# Altium schematic sheet shower.SchDoc
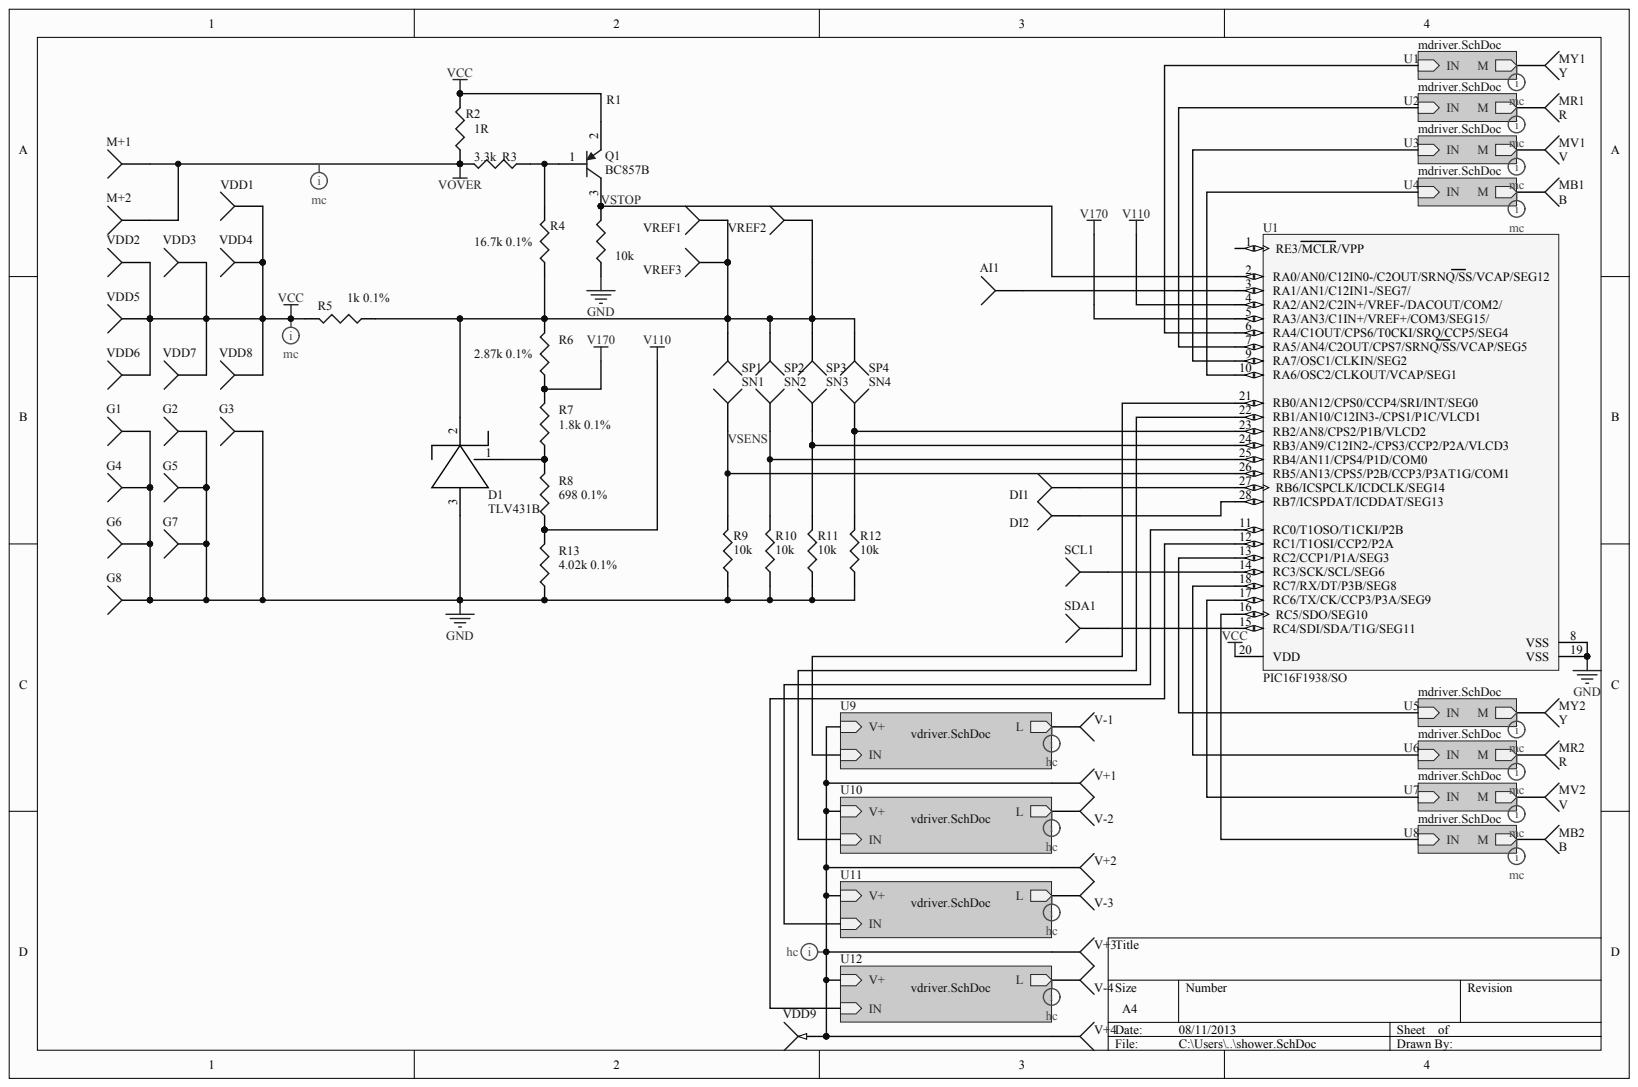
[diagram p0: shower.SchDoc]

## Parts
AI1  +5V  (value not drawn)
D1  TLV431B
DI1  +5V  (value not drawn)
DI2  +5V  (value not drawn)
G1  Socket  (value not drawn)
G2  Socket  (value not drawn)
G3  Socket  (value not drawn)
G4  Socket  (value not drawn)
G5  Socket  (value not drawn)
G6  Socket  (value not drawn)
G7  Socket  (value not drawn)
G8  Socket  (value not drawn)
M+1  +5V  (value not drawn)
M+2  +5V  (value not drawn)
MB1  B
MB2  B
MR1  R
MR2  R
MV1  V
MV2  V
MY1  Y
MY2  Y
Q1  BC857B
R1  10k
R2  1R
R3  3.3k
R4  16.7k 0.1%  value 16.7k
R5  1k 0.1%  value 1k
R6  2.87k 0.1%
R7  1.8k 0.1%  value 1.8k
R8  698 0.1%  value 698
R9  10k
R10  10k
R11  10k
R12  10k
R13  4.02k 0.1%
SCL1  +5V  (value not drawn)
SDA1  +5V  (value not drawn)
SN1  +5V  (value not drawn)
SN2  +5V  (value not drawn)
SN3  +5V  (value not drawn)
SN4  +5V  (value not drawn)
SP1  +5V  (value not drawn)
SP2  +5V  (value not drawn)
SP3  +5V  (value not drawn)
SP4  +5V  (value not drawn)
U1  PIC16F1938/SO
V+1  +5V  (value not drawn)
V+2  +5V  (value not drawn)
V+3  +5V  (value not drawn)
V+4  +5V  (value not drawn)
V-1  +5V  (value not drawn)
V-2  +5V  (value not drawn)
V-3  +5V  (value not drawn)
V-4  +5V  (value not drawn)
VDD1  +5V  (value not drawn)
VDD2  +5V  (value not drawn)
VDD3  +5V  (value not drawn)
VDD4  +5V  (value not drawn)
VDD5  +5V  (value not drawn)
VDD6  +5V  (value not drawn)
VDD7  +5V  (value not drawn)
VDD8  +5V  (value not drawn)
VDD9  +5V  (value not drawn)
VREF1  +5V  (value not drawn)
VREF2  +5V  (value not drawn)
VREF3  +5V  (value not drawn)

## Nets
GND: D1.3 G1.1 G2.1 G3.1 G4.1 G5.1 G6.1 G7.1 G8.1 R1.1 R9.1 R10.1 R11.1 R12.1 R13.1 U1.8(VSS) U1.19(VSS)
V110: R8.1 R13.2 U1.4(RA2/AN2/C2IN+/VREF-/DACOUT/COM2/)
V170: R6.1 R7.2 U1.5(RA3/AN3/C1IN+/VREF+/COM3/SEG15/)
VCC: Q1.2 R2.2 R5.2 U1.20(VDD) VDD1.1 VDD2.1 VDD3.1 VDD4.1 VDD5.1 VDD6.1 VDD7.1 VDD8.1
VOVER: M+1.1 M+2.1 R2.1 R3.2
VSENS: R9.2 SN1.1 U1.26(RB5/AN13/CPS5/P2B/CCP3/P3AT1G/COM1)
VSTOP: Q1.3 R1.2 U1.2(RA0/AN0/C12IN0-/C2OUT/SRNQ/SS/VCAP/SEG12)
NetAI1_1: AI1.1 U1.3(RA1/AN1/C12IN1-/SEG7/)
NetD1_1: D1.1 R7.1 R8.2
NetD1_2: D1.2 R4.1 R5.1 R6.2 SP1.1 SP2.1 SP3.1 SP4.1 VREF1.1 VREF2.1 VREF3.1
NetDI1_1: DI1.1 U1.27(RB6/ICSPCLK/ICDCLK/SEG14)
NetDI2_1: DI2.1 U1.28(RB7/ICSPDAT/ICDDAT/SEG13)
NetMB1_1: MB1.1
NetMB2_1: MB2.1
NetMR1_1: MR1.1
NetMR2_1: MR2.1
NetMV1_1: MV1.1
NetMV2_1: MV2.1
NetMY1_1: MY1.1
NetMY2_1: MY2.1
NetQ1_1: Q1.1 R3.1 R4.2
NetR10_2: R10.2 SN2.1 U1.25(RB4/AN11/CPS4/P1D/COM0)
NetR11_2: R11.2 SN3.1 U1.24(RB3/AN9/C12IN2-/CPS3/CCP2/P2A/VLCD3)
NetR12_2: R12.2 SN4.1 U1.23(RB2/AN8/CPS2/P1B/VLCD2)
NetSCL1_1: SCL1.1 U1.14(RC3/SCK/SCL/SEG6)
NetSDA1_1: SDA1.1 U1.15(RC4/SDI/SDA/T1G/SEG11)
NetU1_1: U1.1(RE3/MCLR/VPP)
NetU1_6: U1.6(RA4/C1OUT/CPS6/T0CKI/SRQ/CCP5/SEG4)
NetU1_7: U1.7(RA5/AN4/C2OUT/CPS7/SRNQ/SS/VCAP/SEG5)
NetU1_9: U1.9(RA7/OSC1/CLKIN/SEG2)
NetU1_10: U1.10(RA6/OSC2/CLKOUT/VCAP/SEG1)
NetU1_11: U1.11(RC0/T1OSO/T1CKI/P2B)
NetU1_12: U1.12(RC1/T1OSI/CCP2/P2A)
NetU1_13: U1.13(RC2/CCP1/P1A/SEG3)
NetU1_16: U1.16(RC5/SDO/SEG10)
NetU1_17: U1.17(RC6/TX/CK/CCP3/P3A/SEG9)
NetU1_18: U1.18(RC7/RX/DT/P3B/SEG8)
NetU1_21: U1.21(RB0/AN12/CPS0/CCP4/SRI/INT/SEG0)
NetU1_22: U1.22(RB1/AN10/C12IN3-/CPS1/P1C/VLCD1)
NetV+1_1: V+1.1 V+2.1 V+3.1 V+4.1 VDD9.1
NetV-1_1: V-1.1
NetV-2_1: V-2.1
NetV-3_1: V-3.1
NetV-4_1: V-4.1

## Library data of the parts
R2 = (no symbol name): Value: 1; Published: 8-Jun-2000; LatestRevisionDate: 29-May-2009; LatestRevisionNote: IPC-7351 Footprint Added.; PackageReference: J1-0603; PackageDescription: Chip Resistor; Publisher: Altium Limited
AI1 D1 DI1 DI2 G1-G8 M+1 M+2 MB1 MB2 MR1 MR2 MV1 MV2 MY1 MY2 Q1 R1 R3-R13 SCL1 SDA1 SN1-SN4 SP1-SP4 U1 V+1-V+4 V-1-V-4 VDD1-VDD9 VREF1-VREF3 = (no symbol name)
  AI1 DI1 DI2 G1-G8 M+1 M+2 MB1 MB2 MR1 MR2 MV1 MV2 MY1 MY2 SCL1 SDA1 SN1-SN4 SP1-SP4 V+1-V+4 V-1-V-4 VDD1-VDD9 VREF1-VREF3: LatestRevisionDate: 17-Jul-2002; LatestRevisionNote: Re-released for DXP Platform.
  Q1: Published: 8-Jun-2000; LatestRevisionDate: 29-May-2009; LatestRevisionNote: IPC-7351 Footprint Added.; PackageVersion: Aug-1999; PackageReference: SOT-23B; PackageDescription: 3-Pin SOT-23 Package 0.95 mm Pitch, 2.4 mm Lead Span
  R1 R9-R12: Published: 8-Jun-2000; LatestRevisionDate: 29-May-2009; LatestRevisionNote: IPC-7351 Footprint Added.; PackageReference: J1-0603; PackageDescription: Chip Resistor; Value: 10K
  R3: Published: 8-Jun-2000; LatestRevisionDate: 29-May-2009; LatestRevisionNote: IPC-7351 Footprint Added.; PackageReference: J1-0603; PackageDescription: Chip Resistor; Value: 3.3K
  R4 R5 R7 R8: Published: 8-Jun-2000; LatestRevisionDate: 29-May-2009; LatestRevisionNote: IPC-7351 Footprint Added.; PackageReference: J1-0603; PackageDescription: Chip Resistor
  R6: Published: 8-Jun-2000; LatestRevisionDate: 29-May-2009; LatestRevisionNote: IPC-7351 Footprint Added.; PackageReference: J1-0603; PackageDescription: Chip Resistor; Value: 2.87K
  R13: Published: 8-Jun-2000; LatestRevisionDate: 29-May-2009; LatestRevisionNote: IPC-7351 Footprint Added.; PackageReference: J1-0603; PackageDescription: Chip Resistor; Value: 4.02K
  U1: Published: 40149; LatestRevisionDate: 11-Aug-2010; LatestRevisionNote: Sch. layout revised.; DatasheetVersion: revC, Sep-2009; PackageVersion: revBB, Aug-2009; PackageReference: SOIC-SO28; PackageDescription: 28-Lead Plastic Small Outline (SO) - Wide 7.50mm Body [SOIC]; Supplier 1: Digi-Key; Supplier Part Number 1: PIC16F1936T-I/SO-ND; Code_JEDEC: MS-013; ComponentLink1Description: Datasheet; ComponentLink1URL: http://ww1.microchip.com/downloads/en/DeviceDoc/41364C.pdf; ComponentLink2Description: Packaging Specification; ComponentLink2URL: http://www.microchip.com/stellent/groups/techpub_sg/documents/packagingspec/en012702.pdf; ComponentLink3Description: Microchip.com Home; ComponentLink3URL: http://www.microchip.com/
  AI1 DI1 DI2 G1-G8 M+1 M+2 MB1 MB2 MR1 MR2 MV1 MV2 MY1 MY2 Q1 R1 R3-R13 SCL1 SDA1 SN1-SN4 SP1-SP4 U1 V+1-V+4 V-1-V-4 VDD1-VDD9 VREF1-VREF3: Publisher: Altium Limited
